# Altium schematic sheet peripheral_1.SchDoc
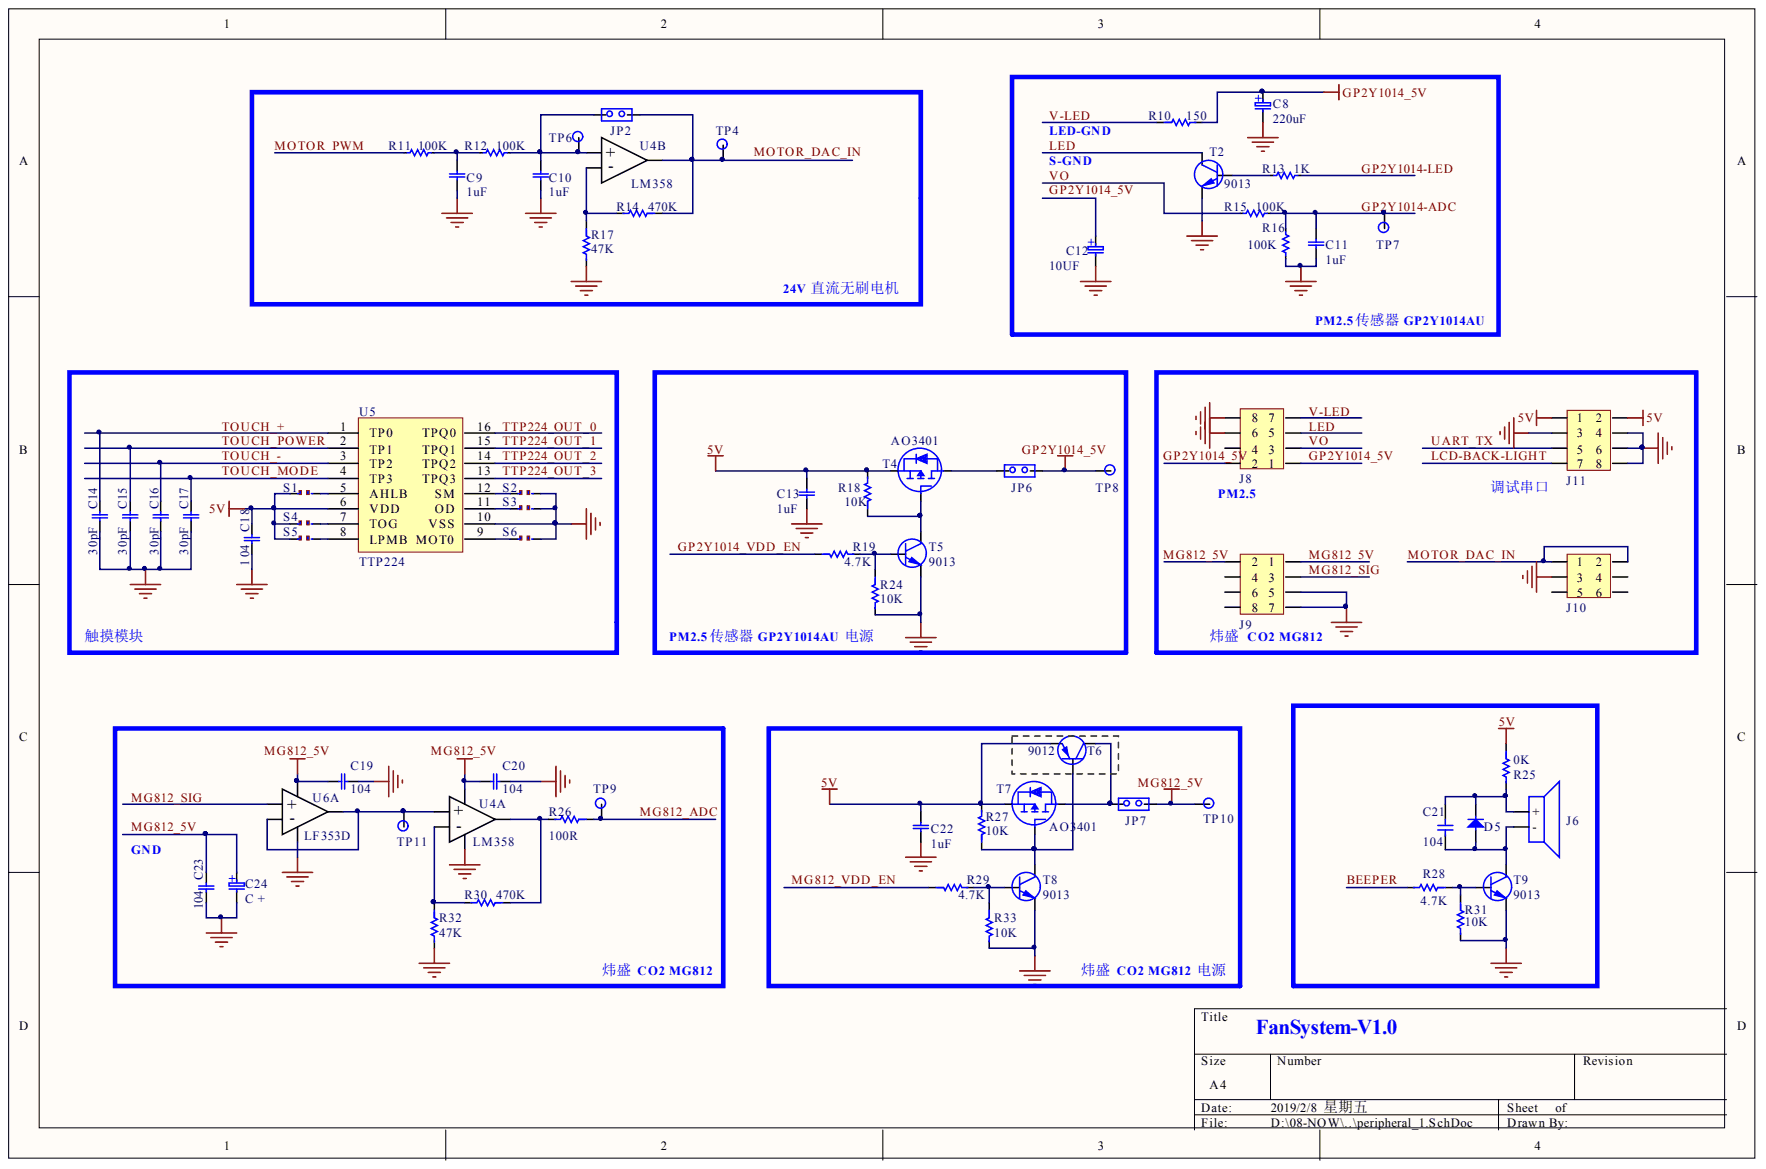
[diagram p0: peripheral_1.SchDoc]

## Parts
C8  220uF
C9  1uF
C10  1uF
C11  1uF
C12  10UF
C13  1uF
C14  30pF
C15  30pF
C16  30pF
C17  30pF
C18  104
C19  104
C20  104
C21  104
C22  1uF
C23  104
C24  C +
D5  D
J6  BEEPER  (value not drawn)
J8  CON4*2  (value not drawn)
J9  CON4*2  (value not drawn)
J10  CON3*2  (value not drawn)
J11  CON4*2  (value not drawn)
JP2  JP-2
JP6  JP-2  (value not drawn)
JP7  JP-2  (value not drawn)
R10  150
R11  100K
R12  100K
R13  1K
R14  470K
R15  100K
R16  100K
R17  47K
R18  10K
R19  4.7K
R24  10K
R25  0K
R26  100R
R27  10K
R28  4.7K
R29  4.7K
R30  470K
R31  10K
R32  47K
R33  10K
S1  S
S2  S
S3  S
S4  S
S5  S
S6  S
T2  9013
T4  AO3401
T5  9013
T6  9012
T7  AO3401
T8  9013
T9  9013
TP4  TP
TP6  TP
TP7  TP
TP8  TP
TP9  TP
TP10  TP
TP11  TP
U4  LM358  (parts A,B)
U5  TTP224
U6  LF353D  (part A)

## Nets
5V: C13.2 C18.2 C22.2 J11.1 J11.2 R18.1 R25.2 R27.1 S1.1 S4.1 S5.1 T4.S T6.2 T7.S U5.6(VDD)
GND: C8.K C9.1 C10.1 C11.1 C12.K C13.1 C14.1 C15.1 C16.1 C17.1 C18.1 C19.2 C20.2 C22.1 C23.2 C24.K J8.6 J8.8 J9.5 J9.7 J10.3 J11.3 J11.4 J11.6 J11.8 R16.1 R17.1 R24.1 R31.1 R32.1 R33.1 S2.2 S3.2 S6.2 T2.2 T5.2 T8.2 T9.2 U4.4 U5.10(VSS) U6.4
BEEPER: R28.1
GP2Y1014-ADC: C11.2 R15.2 R16.2 TP7.1
GP2Y1014-LED: R13.2
GP2Y1014_5V: C8.A C12.A J8.1 J8.2 JP6.2 R10.1 TP8.1
GP2Y1014_VDD_EN: R19.2
LCD-BACK-LIGHT: J11.7
LED: J8.5 T2.3
MG812_5V: C19.1 C20.1 C23.1 C24.A J9.1 J9.2 JP7.2 TP10.1 U4.8 U6.8
MG812_ADC: R26.2 TP9.1
MG812_SIG: J9.3 U6.3(+)
MG812_VDD_EN: R29.2
MOTOR_DAC_IN: J10.1 J10.2 JP2.2 R14.2 TP4.1 U4.7
MOTOR_PWM: R11.1
TOUCH_+: C14.2 U5.1(TP_0)
TOUCH_-: C16.2 U5.3(TP_2)
TOUCH_MODE: C17.2 U5.4(TP_3)
TOUCH_POWER: C15.2 U5.2(TP_1)
TTP224_OUT_0: U5.16(TP_Q_0)
TTP224_OUT_1: U5.15(TP_Q_1)
TTP224_OUT_2: U5.14(TP_Q_2)
TTP224_OUT_3: U5.13(TP_Q_3)
UART_TX: J11.5
V-LED: J8.7 R10.2
VO: J8.3 R15.1
NetC9_2: C9.2 R11.2 R12.1
NetC10_2: C10.2 JP2.1 R12.2 TP6.1 U4.5(+)
NetC21_1: C21.1 D5.K J6.1 R25.1
NetC21_2: C21.2 D5.A J6.2 T9.3
NetJP6_1: JP6.1 T4.D
NetJP7_1: JP7.1 T6.3 T7.D
NetR13_1: R13.1 T2.1
NetR14_1: R14.1 R17.2 U4.6(-)
NetR18_2: R18.2 T4.G T5.3
NetR19_1: R19.1 R24.2 T5.1
NetR26_1: R26.1 R30.2 U4.1
NetR27_2: R27.2 T6.1 T7.G T8.3
NetR28_2: R28.2 R31.2 T9.1
NetR29_1: R29.1 R33.2 T8.1
NetR30_1: R30.1 R32.2 U4.2(-)
NetS1_2: S1.2 U5.5(A_H_LB)
NetS2_1: S2.1 U5.12(SM)
NetS3_1: S3.1 U5.11(OD)
NetS4_2: S4.2 U5.7(TO_G)
NetS5_2: S5.2 U5.8(LP_M_B)
NetS6_1: S6.1 U5.9(M_O_T0)
NetTP11_1: TP11.1 U4.3(+) U6.1 U6.2(-)

Not connected: J8 4; J9 4 6 8; J10 4 5 6
